# Altium schematic sheet BatterySource_RelaisOut.SchDoc(BatterySource_RelaisOut)
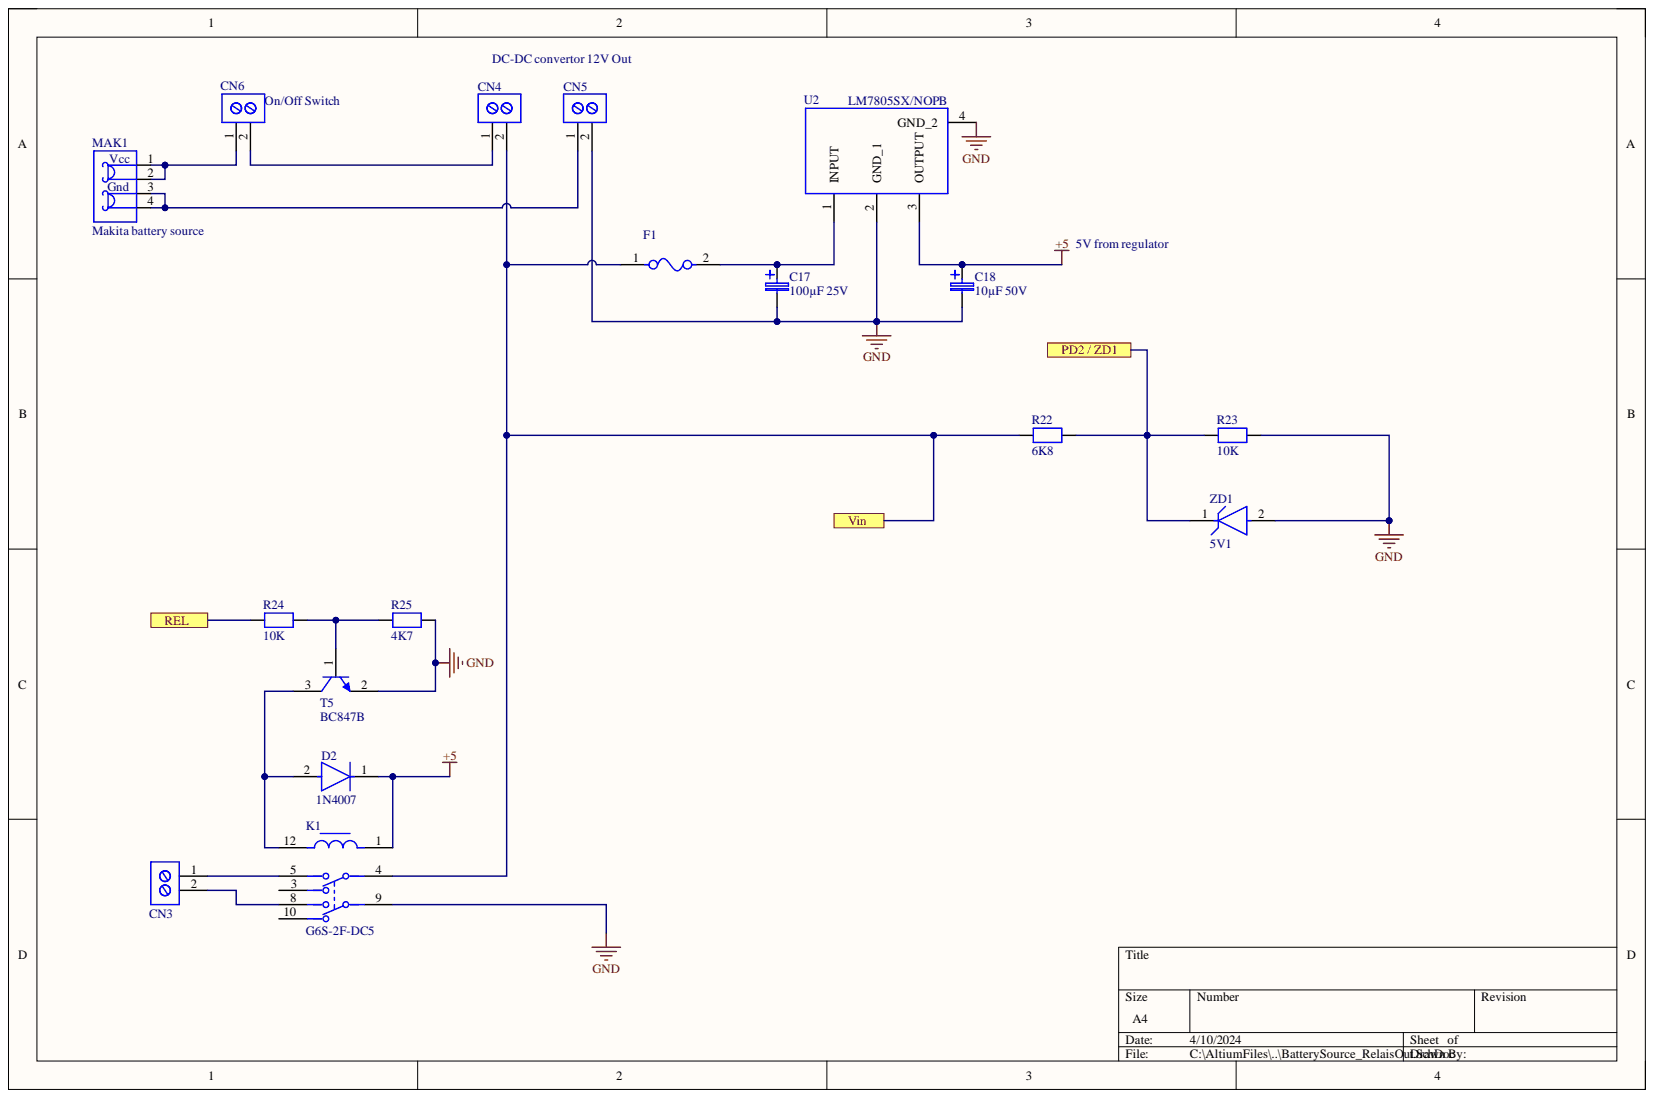
[diagram p0: BatterySource_RelaisOut.SchDoc]

## Parts
C17  100µF 25V  [VISH-293D-E_L]
C18  10µF 50V  [VISH-293D-E_L]
CN3  Printkroonsteen 2 POL  [Printkroonsteen 2pin]  (value not drawn)
CN4  Printkroonsteen 2 POL  [Printkroonsteen 2pin]  (value not drawn)
CN5  Printkroonsteen 2 POL  [Printkroonsteen 2pin]  (value not drawn)
CN6  On/Off Switch  [Printkroonsteen 2pin]
D2  1N4007  [DIODE 1N4007 ONSC-AXIAL_LEAD-2-59-10_P]
F1  (no value)  [FP-0154-MFG]
K1  G6S-2F-DC5  [FP-G6S-2F-DC5-MFG]
MAK1  Makita battery source  [makita foodprint female spade for PCD]
R22  6K8  [RESC2013X50X30LL20T20]
R23  10K  [RESC2013X50X30LL20T20]
R24  10K  [RESC2013X50X30LL20T20]
R25  4K7  [RESC2013X50X30LL20T20]
T5  BC847B  [INF-SOT23_L]
U2  LM7805SX/NOPB  [FP-KTT0003B-MFG]
ZD1  5V1  [Zenerdiode TH]

## Ports
PD2 / ZD1, REL, Vin

## Nets
+5: C18.1 D2.1 K1.1 U2.3(OUTPUT)
GND: C17.2 C18.2 CN5.2 K1.9 R23.2 R25.2 T5.2 U2.2(GND_1) U2.4(GND_2) ZD1.2
PD2 / ZD1: R22.2 R23.1 ZD1.1 ; port PD2 / ZD1
REL: R24.1 ; port REL
Vin: CN4.2 F1.1 K1.4 R22.1 ; port Vin
NetC17_1: C17.1 F1.2 U2.1(INPUT)
NetCN3_1: CN3.1 K1.5
NetCN3_2: CN3.2 K1.8
NetCN4_1: CN4.1 CN6.2
NetCN5_1: CN5.1 MAK1.3 MAK1.4
NetCN6_1: CN6.1 MAK1.1(Vcc) MAK1.2
NetD2_2: D2.2 K1.12 T5.3
NetR24_2: R24.2 R25.1 T5.1

Not connected: K1 3 10

## Library data of the parts
C17 C18 = capacitor THT radial tantalum: Tantalum Capacitors - Solid Leaded 50V 10uF 20% ESR=1.6 Ohm TAP106M050BRW; Manufacturer_Name: Kyocera AVX; Geometry.Height: 15.5mm; Component Kind: Standard; Datasheet Link: https://www.mouser.be/datasheet/2/40/tap-776819.pdf; Library Name: SolderStation.SchLib; Manufacturer_Part_Number: TAP106M050BRW; Mouser Part Number: 581-TAP106M050BRW; Mouser Price/Stock: https://www.mouser.co.uk/ProductDetail/AVX/TAP106M050BRW?qs=GjEaAqXp9rZGx11KfVU76g%3D%3D
CN3-CN6 = printkroonsteen 1 X 2: Printkroonsteen 2 PO L1716020000; Manufacturer_Name: Weidmuller; Component Kind: Standard; Datasheet Link: https://catalog.weidmueller.com/catalog/Start.do?ObjectID=1716020000&_ga=2.21797499.1716783809.1613378202-511725545.1613378202; Library Name: SolderStation.SchLib; Manufacturer_Part_Number: 1716020000; Mouser Part Number: 470-1716020000; Mouser Price/Stock: https://www.mouser.co.uk/ProductDetail/Weidmuller/1716020000?qs=WJDKaGZ8K%252B7GSEFQgvMjVA%3D%3D
D2 = DIODE 1N4007: DIODE 1N4007 1A 1000V; Manufacturer: On Semiconductor; IFSM Max (A): 30; IO(rec) Max (A): 1; Packing: Bulk Bag; Type: Standard Recovery; VRRM Max (V): 1000; Component Kind: Standard; ComponentLink1Description: Manufacturer URL; ComponentLink1URL: http://www.onsemi.com/; ComponentLink2Description: Datasheet; ComponentLink2URL: http://www.onsemi.com/pub_link/Collateral/1N4001-D.PDF; ComponentLink3Description: Package Specification; ComponentLink3URL: http://www.onsemi.cn/pub_link/Collateral/59-10.PDF; DatasheetVersion: Rev. 13; Library Name: SolderStation.SchLib; Mounting Technology: Through Hole; PackageDescription: 2-Pin, Axial Lead, Diameter 2.35 mm; PackageReference: AXIAL_LEAD-2-59-10; PackageVersion: Rev. U, 02/2005; PartNumber: 1N4007; RoHS: TRUE
F1 = Fuse: FUSE BRD MNT 4A 125VAC/VDC 2SMDCMP-08605-000003-1; Manufacturer: Littelfuse; Manufacturer Part Number: 0154004.DR; Fuse Blow Speed: Fast; Max Fuse Ac Voltage: 125V; Automotive: No; Category: Fuse; Component Kind: Standard; ComponentLink2Description: Datasheet; ComponentLink2URL: https://www.littelfuse.com/~/media/electronics/datasheets/fuses/littelfuse_fuse_154_154t_154l_154tl_datasheet.pdf.pdf; Device Class L1: Circuit Protection; Device Class L2: Fuses; Device Class L3: unset; Digikey Description: FUSE BRD MNT 4A 125VAC/VDC 2SMD; Fuse Current: 4A; Height: 3.81mm; Lead Free: Yes; Library Name: SolderStation.SchLib; Max Fuse Dc Voltage: 125V; Mouser Description: Surface Mount Fuses Fuseblock w/ fuse 4A OMNI BLOK 154; Octopart: https://octopart.com/0154004.dr-littelfuse-565861; Package: 0154; RoHS: TRUE; Temperature: -55°C to +125°C; Temperature Range High: +125°C; Temperature Range Low: -55°C
K1 = relais 5V 2A: RELAY TELECOM DPDT 2A 5V DCCMP-08823-000012-1; Manufacturer: Omron; Coil Current: 28.1mA; Coil Power: 140mW; Coil Resistance: 178Ω; Coil Type: Non-Latching; Number Of Contacts: 2; Standoff Height: 0.35mm; Ambient Temperature Range High: +85°C; Ambient Temperature Range Low: -40°C; Automotive: No; Category: Relay; Coil Voltage: 5V; Component Kind: Standard; ComponentLink2Description: Datasheet; ComponentLink2URL: https://omronfs.omron.com/en_US/ecb/products/pdf/en-g6s.pdf; Current Rating: 2A; Device Class L1: Electromechanical; Device Class L2: Relays; Device Class L3: Signal Relays; Digikey Description: RELAY TELECOM DPDT 2A 5VDC; Height: 9.4mm; Lead Free: Yes; Library Name: SolderStation.SchLib; Manufacturer Part Number: G6S-2F-DC5; Mouser Description: Electromechanical Relay 5VDC 178Ohm 2A DPDT (14.8x9.2x9.2)mm SMD Signal Relay; Octopart: https://octopart.com/g6s-2f-dc5-omron-85236; Package: G6S-2F-DC5; RoHS: TRUE; Switching Current: 2A; Temperature: -40°C to +85°C; Temperature Range High: +85°C; Temperature Range Low: -40°C; Throw Configuration: DPDT
MAK1 = Makita battery source: complete set-up for bettery makita terminal p/n 644808-8; Component Kind: Standard; Library Name: SolderStation.SchLib
R22-R25 = resistor 0805: Manufacturer: Vishay Dale; Part Number: CRCW08050000Z0EA; Component Kind: Standard; Library Name: SolderStation.SchLib
T5 = transistor BC847B NPN: NPN Silicon AF Transistor, 45 V VCEO, 100 mA IC, SOT23, Reel, Green CMP-1177-00042-1; Manufacturer: Infineon; fT (typ): 250Â MHz; Green: TRUE; IBM (max): 200Â mA; IC (max): 100Â mA; ICBO (max): 15Â nA; Ptot (max): 330Â mW; VCBO (max): 50Â V; VCEO (max): 45Â V; VEBO (max): 6Â V; Component Kind: Standard; ComponentLink1Description: Manufacturer URL; ComponentLink1URL: http://www.infineon.com/; ComponentLink2Description: Datasheet; ComponentLink2URL: http://www.infineon.com/dgdl/bc847series_bc848series_bc849series_bc850series.pdf?folderId=db3a304314dca389011541d30fa21656&fileId=db3a304314dca389011541d4630a1657; ComponentLink3Description: Package Specification; ComponentLink3URL: http://www.infineon.com/dgdl/SOT23-Package_Overview.pdf?folderId=db3a30431936bc4b0119532290053c66&fileId=db3a30431936bc4b0119533468123c85; ICM (max): 200Â mA; Library Name: SolderStation.SchLib; Mounting Technology: Surface Mount; PackageDescription: 3-Pin Small Outline Transistor Package, 1.3 x 2.9 mm Body, 0.95 mm Pitch; PackageReference: SOT23; PackageVersion: Apr-08; Packing: Reel; PartNumber: BC847B; Polarity: NPN
U2 = VoltageRed LM7805 SMD: IC REG LIN 5V 1.5A DDPAK/TO263-3 CMP-2000-07590-2; Manufacturer: Texas Instruments; Accuracy Percentage: 3%; Dropout Voltage: 2V; Max Junction Temp: +150°C; Max Supply Voltage: 30V; Min Supply Voltage: 7.5V; Nominal Supply Current: 8mA; Number Of Outputs: 1; Output Type: Fixed; Standoff Height: 0mm; Ambient Temperature Range High: +125°C; Ambient Temperature Range Low: 0°C; Automotive: No; Category: IC; Component Kind: Standard; ComponentLink2Description: Datasheet; ComponentLink2URL: http://www.ti.com/lit/ds/symlink/lm7800.pdf; Device Class L1: Integrated Circuits (ICs); Device Class L2: Power Management ICs; Device Class L3: Voltage Regulators - Linear; Digikey Description: IC REG LIN 5V 1.5A DDPAK/TO263-3; Height: 4.79mm; Lead Free: Yes; Library Name: SolderStation.SchLib; Manufacturer Part Number: LM7805SX/NOPB; Mouser Description: 1.5-A, Wide VIN Fixed Voltage Regulators 3-DDPAK/TO-263 0 to 0; Octopart: https://octopart.com/lm7805sx%2Fnopb-texas+instruments-74629994; Output Current: 1.5A; Output Voltage: 5V; Package: KTT0003B; RoHS: TRUE; Temperature: 0°C to +125°C; Temperature Range High: +125°C; Temperature Range Low: 0°C
ZD1 = ZenerDiode: Series 500 mW 5.1 V 200 mA Through Hole Zener Diode - DO-35; Manufacturer Lifecycle Status: ACTIVE; NexarPartId: 13696926; Breakdown Voltage: 9.1V; Element Configuration: Single; ESD Protection: No; Forward Voltage: 1.2V; Impedance: 10Ω; Max Junction Temperature (Tj): 200°C; Max Power Dissipation: 500mW; Max Reverse Leakage Current: 3µA; Reverse Voltage: 7V; Test Current: 20mA; Tolerance: 5%; Case/Package: Axial; Component Kind: Standard; Contact Plating: Tin; Height: 1.91mm; Lead Free: Lead Free; Length: 6.35m; Library Name: SolderStation.SchLib; Lifecycle Status: Production; Max Operating Temperature: 200°C; Min Operating Temperature: -65°C; Mount: Through Hole; Number of Pins: 2; Packaging: Bulk; Peak Reverse Current: 3µA; Power Dissipation: 500mW; Power Rating: 500mW; Radiation Hardening: No; REACH SVHC: No SVHC; RoHS: Compliant; Schedule B: 8541100050, 8541100050|8541100050|8541100050|8541100050|8541100050; Voltage Rating (DC): 9.1V; Voltage Tolerance: 5%; Weight: 80g; Width: 6.35m; Working Voltage: 9.1V; Zener Current: 20mA; Zener Voltage: 9.1V
